# Altium schematic sheet Sheet4.SchDoc
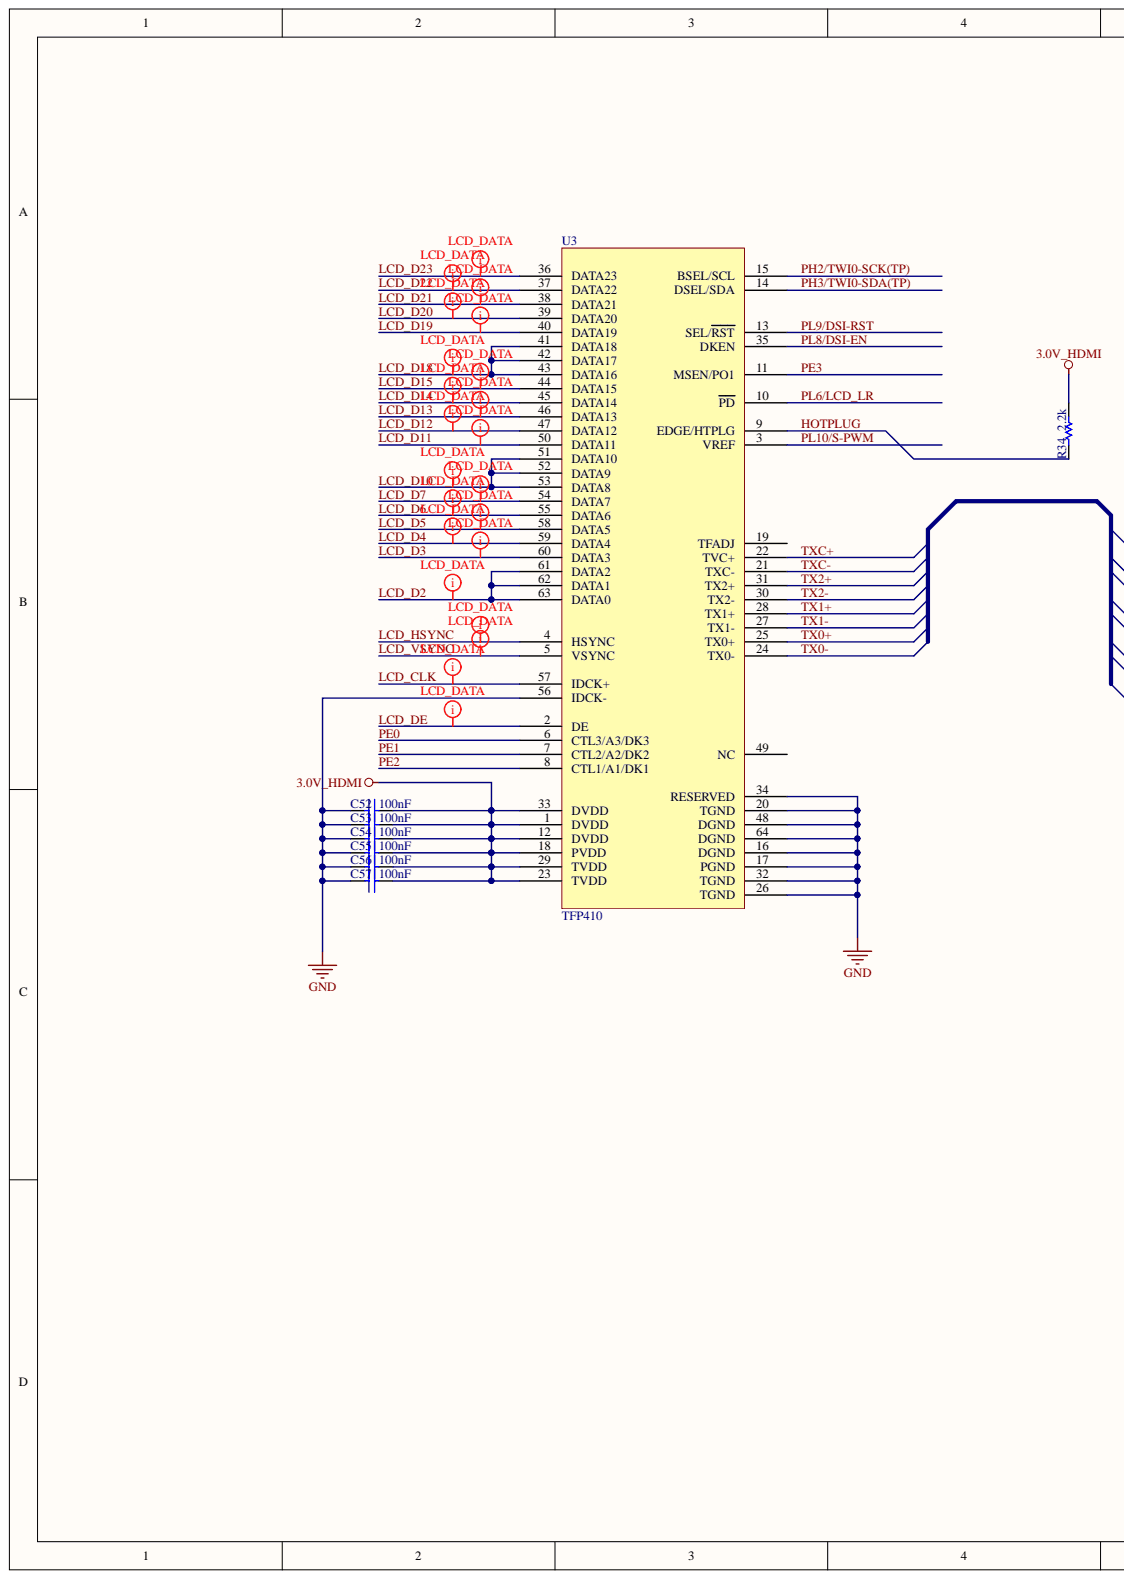
[diagram p0: Sheet4.SchDoc, left]
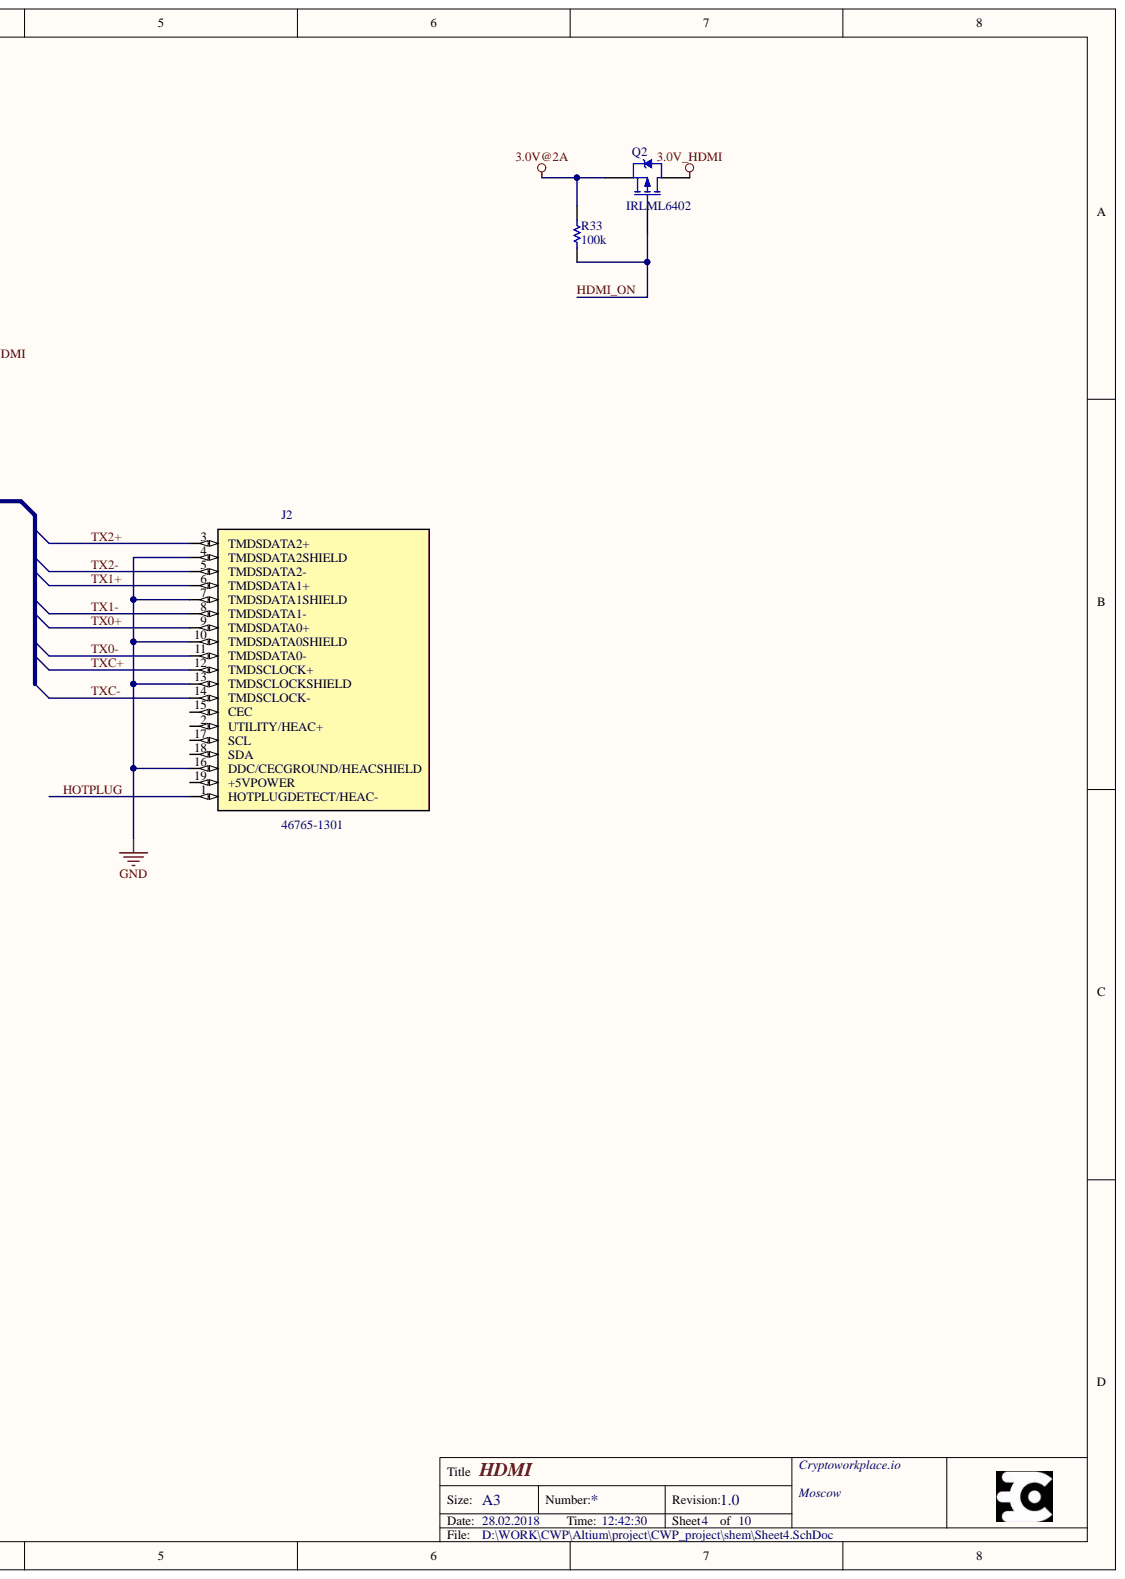
[diagram p1: Sheet4.SchDoc, right]

## Parts
C52  value 100nF  [0402(CAPC1005X06N)]  (hidden comment: 0402)
C53  value 100nF  [0402(CAPC1005X06N)]  (hidden comment: 0402)
C54  value 100nF  [0402(CAPC1005X06N)]  (hidden comment: 0402)
C55  value 100nF  [0402(CAPC1005X06N)]  (hidden comment: 0402)
C56  value 100nF  [0402(CAPC1005X06N)]  (hidden comment: 0402)
C57  value 100nF  [0402(CAPC1005X06N)]  (hidden comment: 0402)
J2  46765-1301  [46765-1301]
Q2  IRLML6402  [IRLML6402]
R33  value 100k  [0402(RESC1005X04N)]  (hidden comment: Res1)
R34  value 2.2k  [0402(RESC1005X04N)]  (hidden comment: Res1)
U3  TFP410  [PAP (S_PQFP-G64)]

## Nets
3.0V@2A: Q2.3 R33.1
3.0V_HDMI: C52.1 C53.1 C54.1 C55.1 C56.1 C57.1 Q2.2 R34.2 U3.1(DVDD) U3.12(DVDD) U3.18(PVDD) U3.23(TVDD) U3.29(TVDD) U3.33(DVDD)
GND: C52.2 C53.2 C54.2 C55.2 C56.2 C57.2 J2.4(TMDSDATA2SHIELD) J2.7(TMDSDATA1SHIELD) J2.10(TMDSDATA0SHIELD) J2.13(TMDSCLOCKSHIELD) J2.16(DDC/CECGROUND/HEACSHIELD) U3.16(DGND) U3.17(PGND) U3.20(TGND) U3.26(TGND) U3.32(TGND) U3.34(RESERVED) U3.48(DGND) U3.56(IDCK-) U3.64(DGND)
HDMI_ON: Q2.1 R33.2
HOTPLUG: J2.1(HOTPLUGDETECT/HEAC-) R34.1 U3.9(EDGE/HTPLG)
LCD_CLK: U3.57(IDCK+)
LCD_D2: U3.61(DATA2) U3.62(DATA1) U3.63(DATA0)
LCD_D3: U3.60(DATA3)
LCD_D4: U3.59(DATA4)
LCD_D5: U3.58(DATA5)
LCD_D6: U3.55(DATA6)
LCD_D7: U3.54(DATA7)
LCD_D10: U3.51(DATA10) U3.52(DATA9) U3.53(DATA8)
LCD_D11: U3.50(DATA11)
LCD_D12: U3.47(DATA12)
LCD_D13: U3.46(DATA13)
LCD_D14: U3.45(DATA14)
LCD_D15: U3.44(DATA15)
LCD_D18: U3.41(DATA18) U3.42(DATA17) U3.43(DATA16)
LCD_D19: U3.40(DATA19)
LCD_D20: U3.39(DATA20)
LCD_D21: U3.38(DATA21)
LCD_D22: U3.37(DATA22)
LCD_D23: U3.36(DATA23)
LCD_DE: U3.2(DE)
LCD_HSYNC: U3.4(HSYNC)
LCD_VSYNC: U3.5(VSYNC)
PE0: U3.6(CTL3/A3/DK3)
PE1: U3.7(CTL2/A2/DK2)
PE2: U3.8(CTL1/A1/DK1)
PE3: U3.11(MSEN/PO1)
PH2/TWI0-SCK(TP): U3.15(BSEL/SCL)
PH3/TWI0-SDA(TP): U3.14(DSEL/SDA)
PL6/LCD_LR: U3.10(PD)
PL8/DSI-EN: U3.35(DKEN)
PL9/DSI-RST: U3.13(SEL/RST)
PL10/S-PWM: U3.3(VREF)
TX0+: J2.9(TMDSDATA0+) U3.25(TX0+)
TX0-: J2.11(TMDSDATA0-) U3.24(TX0-)
TX1+: J2.6(TMDSDATA1+) U3.28(TX1+)
TX1-: J2.8(TMDSDATA1-) U3.27(TX1-)
TX2+: J2.3(TMDSDATA2+) U3.31(TX2+)
TX2-: J2.5(TMDSDATA2-) U3.30(TX2-)
TXC+: J2.12(TMDSCLOCK+) U3.22(TVC+)
TXC-: J2.14(TMDSCLOCK-) U3.21(TXC-)
N00295:

Not connected: J2 2(UTILITY/HEAC+) 15(CEC) 17(SCL) 18(SDA) 19(+5VPOWER); U3 19(TFADJ) 49(NC)

## Library data of the parts
C52-C57 = Cap: Capacitor; Component Kind: Standard; LatestRevisionDate: 17-Jul-2002; LatestRevisionNote: Re-released for DXP Platform.; Library Name: Miscellaneous Devices.IntLib; PackageDescription: Radial Cap, Thru-Hole; 2 Leads; 0.3 in Pin Spacing; PackageReference: RAD-0.3; Published: 8-Jun-2000; Signal Integrity: Cap; Simulation: CAP; Publisher: Altium Limited
J2 = 685119248223: WR-COM ANGLED SMT MICRO HDMI; MF: Wurth Electronics; Availability: Unavailable; Component Kind: Standard; Library Name: 685119248223.SchLib; MP: 685119248223; Package: None; Price: 2.35 USD
Q2 = PMOS-2: P-Channel Power MOSFET; Note: Pin 4 not drawn and electrically connected to Pin 2.; Component Kind: Standard; ComponentLink1Description: Manufacturer Link; ComponentLink1URL: http://www.irf.com/; DatasheetVersion: PD-9.390D; LatestRevisionDate: 17-Jul-2002; LatestRevisionNote: Re-released for DXP Platform.; Library Name: Miscellaneous Devices.IntLib; PackageDescription: TO, Thru-Hole, Vertical, Heatsink Mounted; 3 In-Line Leads; Pitch 2.54 mm; PackageReference: TO-220AB; PackageVersion: Feb-1997; Published: 8-Jun-2000; Simulation: IRF9510; Publisher: Altium Limited
R33 R34 = Res1: Resistor; Component Kind: Standard; LatestRevisionDate: 17-Jul-2002; LatestRevisionNote: Re-released for DXP Platform.; Library Name: Miscellaneous Devices.IntLib; PackageDescription: Axial Device, Thru-Hole; 2 Leads; 0.3 in Pin Spacing; PackageReference: AXIAL-0.3; Published: 8-Jun-2000; Signal Integrity: Res; Simulation: RESISTOR; Publisher: Altium Limited
U3 = TFP410: Component Kind: Standard; Description: TFP410; Library Name: TI_HDMI.SchLib
